# Altium schematic sheet voltage_sense.SchDoc
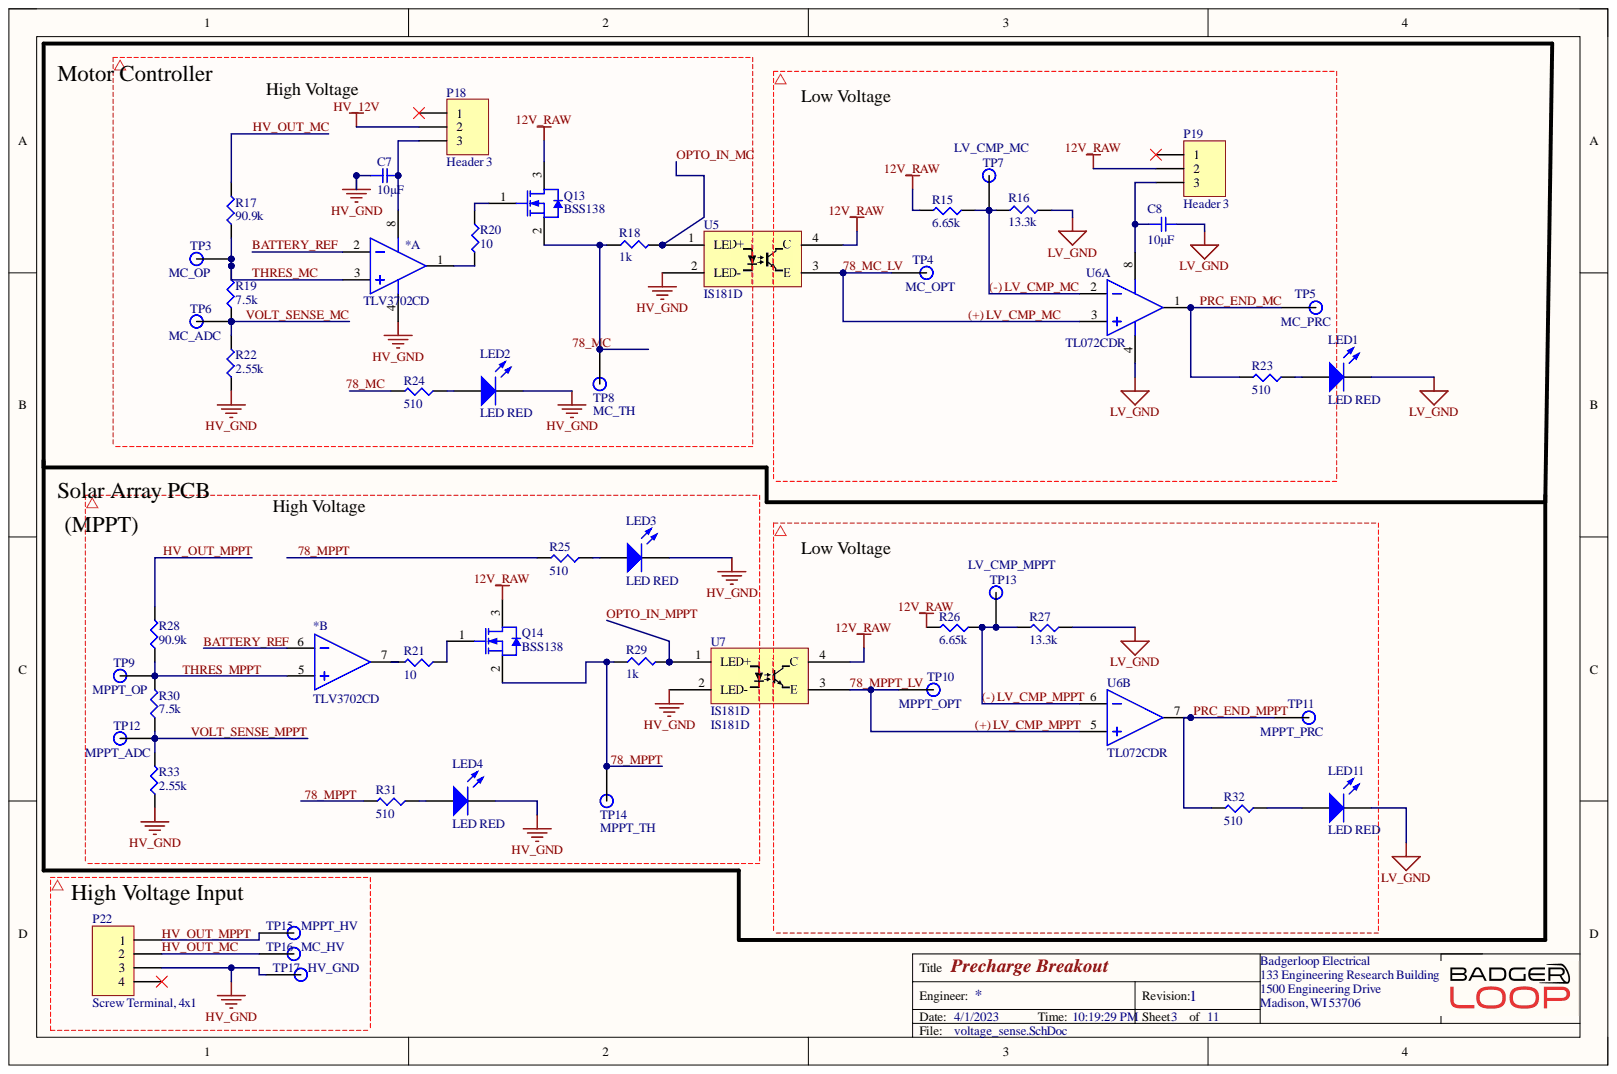
[diagram p0: voltage_sense.SchDoc]

## Parts
*  TLV3702CD  [TLV3702CD-Footprint-1]  (parts A,B)
C7  value 10µF  [SMD-0805C]  (hidden comment: GCM21BR71A106KE22L)
C8  value 10µF  [SMD-0805C]  (hidden comment: GCM21BR71A106KE22L)
LED1  LED RED  [LED 0603 ORANGE]
LED2  LED RED  [LED 0603 ORANGE]
LED3  LED RED  [LED 0603 ORANGE]
LED4  LED RED  [LED 0603 ORANGE]
LED11  LED RED  [LED 0603 ORANGE]
P18  Header 3  [Pin Header 1x3 TH Pitch 2.54mm]
P19  Header 3  [Pin Header 1x3 TH Pitch 2.54mm]
P22  Screw Terminal, 4x1  [4POS TERM BLOCK]
Q13  BSS138  [FAIR-SOT23-3_V]
Q14  BSS138  [FAIR-SOT23-3_V]
R15  value 6.65k  [SMD-0805-RES]  (hidden comment: ERJ-6ENF6651V)
R16  value 13.3k  [SMD-0805-RES]  (hidden comment: ERJ-6ENF1332V)
R17  value 90.9k  [SMD-1206-RES]  (hidden comment: ERJ-8ENF9092V)
R18  value 1k  [SMD-0805-RES]  (hidden comment: ERJ-P06F1001V)
R19  value 7.5k  [SMD-0805-RES]  (hidden comment: ERJ-6GEYJ752V)
R20  10  [SMD-0805-RES]
R21  10  [SMD-0805-RES]
R22  value 2.55k  [SMD-1206-RES]  (hidden comment: ERJ-8ENF2551V)
R23  value 510  [SMD-0805-RES]  (hidden comment: ERJ-P06J511V)
R24  value 510  [SMD-0805-RES]  (hidden comment: ERJ-P06J511V)
R25  value 510  [SMD-0805-RES]  (hidden comment: ERJ-P06J511V)
R26  value 6.65k  [SMD-0805-RES]  (hidden comment: ERJ-6ENF6651V)
R27  value 13.3k  [SMD-0805-RES]  (hidden comment: ERJ-6ENF1332V)
R28  value 90.9k  [SMD-1206-RES]  (hidden comment: ERJ-8ENF9092V)
R29  value 1k  [SMD-0805-RES]  (hidden comment: ERJ-P06F1001V)
R30  value 7.5k  [SMD-0805-RES]  (hidden comment: ERJ-6GEYJ752V)
R31  value 510  [SMD-0805-RES]  (hidden comment: ERJ-P06J511V)
R32  value 510  [SMD-0805-RES]  (hidden comment: ERJ-P06J511V)
R33  value 2.55k  [SMD-1206-RES]  (hidden comment: ERJ-8ENF2551V)
TP3  MC_OP  [Test Point]
TP4  MC_OPT  [Test Point]
TP5  MC_PRC  [Test Point]
TP6  MC_ADC  [Test Point]
TP7  LV_CMP_MC  [Test Point]
TP8  MC_TH  [Test Point]
TP9  MPPT_OP  [Test Point]
TP10  MPPT_OPT  [Test Point]
TP11  MPPT_PRC  [Test Point]
TP12  MPPT_ADC  [Test Point]
TP13  LV_CMP_MPPT  [Test Point]
TP14  MPPT_TH  [Test Point]
TP15  MPPT_HV  [Test Point]
TP16  MC_HV  [Test Point]
TP17  HV_GND  [Test Point]
U5  IS181D  [IS181D]
U6  TL072CDR  [SOP127P600X175-8N]  (parts A,B)
U7  IS181D  [IS181D]

## Nets
12V_RAW: P19.2 Q13.3 Q14.3 R15.2 R26.2 U5.4(C) U7.4(C)
HV_12V: P18.2
HV_GND: *.4 C7.1 LED2.2 LED3.2 LED4.2 P22.3 R22.1 R33.1 TP17.1 U5.2(LED-) U7.2(LED-)
LV_GND: C8.2 LED1.2 LED11.2 R16.2 R27.1 U6.4
78_MC: Q13.2 R18.1 R24.2 TP8.1
78_MC_LV: TP4.1 U5.3(E) U6.3
78_MPPT: Q14.2 R25.2 R29.1 R31.2 TP14.1
78_MPPT_LV: TP10.1 U6.5 U7.3(E)
(-) LV_CMP_MC: R15.1 R16.1 TP7.1 U6.2
(-) LV_CMP_MPPT: R26.1 R27.2 TP13.1 U6.6
BATTERY_REF: *.2 *.6
HV_OUT_MC: P22.2 R17.2 TP16.1
HV_OUT_MPPT: P22.1 R28.2 TP15.1
OPTO_IN_MC: R18.2 U5.1(LED+)
OPTO_IN_MPPT: R29.2 U7.1(LED+)
PRC_END_MC: R23.2 TP5.1 U6.1
THRES_MC: *.3 R17.1 R19.2 TP3.1
THRES_MPPT: *.5 R28.1 R30.2 TP9.1
VOLT_SENSE_MC: R19.1 R22.2 TP6.1
VOLT_SENSE_MPPT: R30.1 R33.2 TP12.1
Net*_1: *.1 R20.2
Net*_7: *.7 R21.2
Net*_8: *.8 C7.2 P18.3
NetC8_1: C8.1 P19.3 U6.8
NetLED1_1: LED1.1 R23.1
NetLED2_1: LED2.1 R24.1
NetLED3_1: LED3.1 R25.1
NetLED4_1: LED4.1 R31.1
NetLED11_1: LED11.1 R32.1
NetQ13_1: Q13.1 R20.1
NetQ14_1: Q14.1 R21.1
NetR32_2: R32.2 TP11.1 U6.7
PRC_END_MPPT:

Not connected: P18 1; P19 1; P22 4

## Library data of the parts
* = CMP-014-00010-1: Comparator, 2 Func, 7000uV Offset-Max, 240000ns Response Time, CMOS, PDSO8; Output Type: PUSH-PULL; Component Kind: Standard; Library Name: Altium Concord Pro on A365; Max Operating Temperature: 70°C; Min Operating Temperature: 0°C; RoHS Compliant: Yes
C7 C8 = CMP-001-00205-1: CAP CER 10UF 10V X7R 0805; Manufacturer: Murata Electronics North America; @ qty: 0; Minimum Quantity: 1; Tolerance: 10%; Unit Price (USD): 0.49; Applications: Automotive; Component Kind: Standard; Datasheets: http://www.murata.com/~/media/webrenewal/support/library/catalog/products/capacitor/mlcc/c03e.ashx; Design Item ID: GCM21BR71A106KE22L; Digi-Key Part Number: 490-10674-1-ND; Factory Stock: 0; Features: -; Height - Seated (Max): -; Image: //media.digikey.com/photos/Murata%20Photos/NCP,GCM%20SERIES%200805.jpg; Lead Spacing: -; Lead Style: -; Library Name: Altium Concord Pro on A365; Mounting Type: Surface Mount, MLCC; Operating Temperature: -55°C ~ 125°C; Package / Case: 0805 (2012 Metric); Packaging: Cut Tape (CT); Part Number: GCM21BR71A106KE22L; Part Status: Active; Quantity Available: 125344; Ratings: AEC-Q200; Series: GCM; Source: capacitors.SchLib; Temperature Coefficient: X7R; Voltage: 10V
LED1-LED4 LED11 = CMP-040-000018-1: A-0603UOC; Manufacturer: Lighthouse LEDs; Color: ORANGE; Minimum Quantity: 1; Availability: In Stock; Component Kind: Standard; ComponentLink1Description: Manufacturer; ComponentLink1URL: https://lighthouseleds.com/0603-smd-led-orange-ultra-bright-170-mcd.html; Datasheets: https://lighthouseleds.com/downloads/dl/file/id/11/0603_orange_amber_smd_led_datasheet.pdf; Design Item ID: LED ORANGE 0603; Library Name: Altium Concord Pro on A365; Manufacturer P/N: A-0603UOC; Package / Case: 0603; Source: misc.SchLib
P18 P19 = CMP-002-00073-1: Header, 3-Pin; Component Kind: Standard; Design Item ID: Header 3; LatestRevisionDate: 17-Jul-2002; LatestRevisionNote: Re-released for DXP Platform.; Library Name: Altium Concord Pro on A365; Source: connector.SchLib; Type: Connector; Publisher: Altium Limited
P22 = CMP-008-000002-1: Screw Terminal, 4-Pin; Component Kind: Standard; Design Item ID: Screw Terminal, 4x1; LatestRevisionDate: 17-Jul-2002; LatestRevisionNote: Re-released for DXP Platform.; Library Name: Altium Concord Pro on A365; Source: connector.SchLib; Type: Connector; Publisher: Altium Limited
Q13 Q14 = CMP-013-00021-4: N-Channel Logic Level Enhancement Mode Field Effect Transistor, 220 mA, 50 V, -55 to 150 degC, 3-Pin SOT-23, RoHS, Tape and Reel; Manufacturer: Fairchild; Elements: 1; Input Capacitance: 27pF; Nominal Dual Supply Voltage: 50V; Nominal Vgs: 1.3V; Package Version: Rev. 12, 03/2016; Power: 360mW; Rds On Max: 3.5Ohm; Resistance: 0.7Ohm; Typical Rise Time: 9ns; Case/Package: TO-236-3; Component Kind: Standard; Datasheet URL: https://www.fairchildsemi.com/datasheets/BS/BSS138.pdf; Datasheet Version: Rev. C, 10/2005; Library Name: Altium Concord Pro on A365; Max Operating Temperature: 150°C; Min Operating Temperature: -55°C; Mounting Technology: SM; Package Description: 3-Pin Small Outline Transistor, Body 2.92 x 1.325 mm, Pitch 0.95 mm; Package Quantity: 1; Package Reference: SOT23-3; Packaging: TapeandReel; Pins: 3; RoHS Compliant: True
R15 R26 = CMP-009-01502-1: RES SMD 6.65K OHM 1% 1/8W 0805; Manufacturer: Panasonic Electronic Components; @ qty: 1500; Factory Stock: 0; Minimum Quantity: 1; Power (Watts): 0.125W, 1/8W; Temperature Coefficient: ±100ppm/°C; Unit Price (USD): 0.00729; Component Kind: Standard; Composition: Thick Film; Datasheets: http://industrial.panasonic.com/www-cgi/jvcr13pz.cgi?E+PZ+3+AOA0002+ERJ6ENF6651V+7+WW; Design Item ID: ERJ-6ENF6651V; Digi-Key Part Number: P6.65KCCT-ND; Failure Rate: -; Features: Automotive AEC-Q200; Height - Seated (Max): 0.028" (0.70mm); Image: //media.digikey.com/Renders/Panasonic%20Renders/ERJ-0805%20Pkg.jpg; Library Name: Altium Concord Pro on A365; Number of Terminations: 2; Operating Temperature: -55°C ~ 155°C; Package / Case: 0805 (2012 Metric); Packaging: Cut Tape (CT); Part Number: ERJ-6ENF6651V; Part Status: Active; Quantity Available: 36879; Series: ERJ; Size / Dimension: 0.079" L x 0.049" W (2.00mm x 1.25mm); Source: resistors.SchLib; Supplier Device Package: 0805; Tolerance: 1%
R16 R27 = CMP-009-01074-1: RES SMD 13.3K OHM 1% 1/8W 0805; Manufacturer: Panasonic Electronic Components; @ qty: 1500; Factory Stock: 0; Minimum Quantity: 1; Power (Watts): 0.125W, 1/8W; Temperature Coefficient: ±100ppm/°C; Unit Price (USD): 0.00729; Component Kind: Standard; Composition: Thick Film; Datasheets: http://industrial.panasonic.com/www-cgi/jvcr13pz.cgi?E+PZ+3+AOA0002+ERJ6ENF1332V+7+WW; Design Item ID: ERJ-6ENF1332V; Digi-Key Part Number: P13.3KCCT-ND; Failure Rate: -; Features: Automotive AEC-Q200; Height - Seated (Max): 0.028" (0.70mm); Image: //media.digikey.com/Renders/Panasonic%20Renders/ERJ-0805%20Pkg.jpg; Library Name: Altium Concord Pro on A365; Number of Terminations: 2; Operating Temperature: -55°C ~ 155°C; Package / Case: 0805 (2012 Metric); Packaging: Cut Tape (CT); Part Number: ERJ-6ENF1332V; Part Status: Active; Quantity Available: 24077; Series: ERJ; Size / Dimension: 0.079" L x 0.049" W (2.00mm x 1.25mm); Source: resistors.SchLib; Supplier Device Package: 0805; Tolerance: 1%
R17 R28 = CMP-009-02429-1: RES SMD 90.9K OHM 1% 1/4W 1206; Manufacturer: Panasonic Electronic Components; @ qty: 1500; Factory Stock: 0; Minimum Quantity: 1; Power (Watts): 0.25W, 1/4W; Temperature Coefficient: ±100ppm/°C; Unit Price (USD): 0.00933; Component Kind: Standard; Composition: Thick Film; Datasheets: http://industrial.panasonic.com/www-cgi/jvcr13pz.cgi?E+PZ+3+AOA0002+ERJ8ENF9092V+7+WW; Design Item ID: ERJ-8ENF9092V; Digi-Key Part Number: P90.9KFCT-ND; Failure Rate: -; Features: Automotive AEC-Q200; Image: //media.digikey.com/Renders/Panasonic%20Renders/ERJ-1206%20Pkg.jpg; Library Name: Altium Concord Pro on A365; Number of Terminations: 2; Operating Temperature: -55°C ~ 155°C; Package / Case: 1206 (3216 Metric); Packaging: Cut Tape (CT); Part Number: ERJ-8ENF9092V; Part Status: Active; Quantity Available: 48984; Series: ERJ; Source: resistors.SchLib; Supplier Device Package: 1206; Tolerance: 1%
R18 R29 = CMP-009-02659-1: RES SMD 1K OHM 1% 1/2W 0805; Manufacturer: Panasonic Electronic Components; @ qty: 1500; Factory Stock: 0; Minimum Quantity: 1; Power (Watts): 0.5W, 1/2W; Temperature Coefficient: ±100ppm/°C; Unit Price (USD): 0.02534; Component Kind: Standard; Composition: Thick Film; Datasheets: https://industrial.panasonic.com/cdbs/www-data/pdf/RDA0000/AOA0000C243.pdf; Design Item ID: ERJ-P06F1001V; Digi-Key Part Number: P16058CT-ND; Failure Rate: -; Features: Automotive AEC-Q200, Pulse Withstanding; Image: //media.digikey.com/Renders/Panasonic%20Renders/ERJ-0805%20Pkg.jpg; Library Name: Altium Concord Pro on A365; Number of Terminations: 2; Operating Temperature: -55°C ~ 155°C; Package / Case: 0805 (2012 Metric); Packaging: Cut Tape (CT); Part Number: ERJ-P06F1001V; Part Status: Active; Quantity Available: 161264; Series: ERJ; Source: resistors.SchLib; Supplier Device Package: 0805; Tolerance: 1%
R19 R30 = CMP-009-01751-1: RES SMD 7.5K OHM 5% 1/8W 0805; Manufacturer: Panasonic Electronic Components; @ qty: 1500; Factory Stock: 0; Minimum Quantity: 1; Power (Watts): 0.125W, 1/8W; Temperature Coefficient: ±200ppm/°C; Unit Price (USD): 0.00496; Component Kind: Standard; Composition: Thick Film; Datasheets: http://industrial.panasonic.com/www-cgi/jvcr13pz.cgi?E+PZ+3+AOA0001+ERJ6GEYJ752V+7+WW; Design Item ID: ERJ-6GEYJ752V; Digi-Key Part Number: P7.5KACT-ND; Failure Rate: -; Features: Automotive AEC-Q200; Image: //media.digikey.com/Renders/Panasonic%20Renders/ERJ-0805%20Pkg.jpg; Library Name: Altium Concord Pro on A365; Number of Terminations: 2; Operating Temperature: -55°C ~ 155°C; Package / Case: 0805 (2012 Metric); Packaging: Cut Tape (CT); Part Number: ERJ-6GEYJ752V; Part Status: Active; Quantity Available: 39543; Series: ERJ; Source: resistors.SchLib; Supplier Device Package: 0805; Tolerance: 5%
R20 R21 = ERJ-6GEYJ100V: RES SMD 10 OHM 5% 1/8W 0805; Manufacturer: Panasonic Electronic Components; @ qty: 1500; Factory Stock: 0; Minimum Quantity: 1; Power (Watts): 0.125W, 1/8W; Temperature Coefficient: ±200ppm/°C; Unit Price (USD): 0.00496; Component Kind: Standard; Composition: Thick Film; Datasheets: http://industrial.panasonic.com/www-cgi/jvcr13pz.cgi?E+PZ+3+AOA0001+ERJ6GEYJ100V+7+WW; Digi-Key Part Number: P10ACT-ND; Failure Rate: -; Features: Automotive AEC-Q200; Height - Seated (Max): 0.028" (0.70mm); Image: //media.digikey.com/Renders/Panasonic%20Renders/ERJ-0805%20Pkg.jpg; Library Name: resistors.SchLib; Number of Terminations: 2; Operating Temperature: -55°C ~ 155°C; Package / Case: 0805 (2012 Metric); Packaging: Cut Tape (CT); Part Number: ERJ-6GEYJ100V; Part Status: Active; Quantity Available: 282033; Series: ERJ; Size / Dimension: 0.079" L x 0.049" W (2.00mm x 1.25mm); Supplier Device Package: 0805; Tolerance: ±5%; Value: 10
R22 R33 = CMP-009-02103-1: RES SMD 2.55K OHM 1% 1/4W 1206; Manufacturer: Panasonic Electronic Components; @ qty: 1500; Factory Stock: 0; Minimum Quantity: 1; Power (Watts): 0.25W, 1/4W; Temperature Coefficient: ±100ppm/°C; Unit Price (USD): 0.00933; Component Kind: Standard; Composition: Thick Film; Datasheets: http://industrial.panasonic.com/www-cgi/jvcr13pz.cgi?E+PZ+3+AOA0002+ERJ8ENF2551V+7+WW; Design Item ID: ERJ-8ENF2551V; Digi-Key Part Number: P2.55KFCT-ND; Failure Rate: -; Features: Automotive AEC-Q200; Image: //media.digikey.com/Renders/Panasonic%20Renders/ERJ-1206%20Pkg.jpg; Library Name: Altium Concord Pro on A365; Number of Terminations: 2; Operating Temperature: -55°C ~ 155°C; Package / Case: 1206 (3216 Metric); Packaging: Cut Tape (CT); Part Number: ERJ-8ENF2551V; Part Status: Active; Quantity Available: 30143; Series: ERJ; Source: resistors.SchLib; Supplier Device Package: 1206; Tolerance: 1%
R23-R25 R31 R32 = CMP-009-00645-1: RES SMD 510 OHM 5% 1/2W 0805; Manufacturer: Panasonic Electronic Components; @ qty: 1500; Factory Stock: 0; Minimum Quantity: 1; Power (Watts): 0.5W, 1/2W; Temperature Coefficient: ±200ppm/°C; Unit Price (USD): 0.01839; Component Kind: Standard; Composition: Thick Film; Datasheets: https://industrial.panasonic.com/cdbs/www-data/pdf/RDA0000/AOA0000C243.pdf; Design Item ID: ERJ-P06J511V; Digi-Key Part Number: P510ADCT-ND; Failure Rate: -; Features: Automotive AEC-Q200, Pulse Withstanding; Height - Seated (Max): 0.028" (0.70mm); Image: //media.digikey.com/Renders/Panasonic%20Renders/ERJ-0805%20Pkg.jpg; Library Name: Altium Concord Pro on A365; Number of Terminations: 2; Operating Temperature: -55°C ~ 155°C; Package / Case: 0805 (2012 Metric); Packaging: Cut Tape (CT); Part Number: ERJ-P06J511V; Part Status: Active; Quantity Available: 54515; Series: ERJ; Size / Dimension: 0.079" L x 0.049" W (2.00mm x 1.25mm); Source: resistors.SchLib; Supplier Device Package: 0805; Tolerance: 5%
TP3-TP17 = CMP-039-000000-1: SMD TEST POINT; Component Kind: Standard; Design Item ID: TP; Library Name: Altium Concord Pro on A365; Source: misc.SchLib
U5 U7 = CMP-020-000001-1: Transistor Output Optocoupler; Manufacturer: Isocom; Category: Optocouplers; Component Kind: Standard; ComponentLink1Description: https://datasheet.ciiva.com:443/pdfs/VipMasterIC/IC/ISCL/ISCL-S-A0004892693/ISCL-S-A0004892693-1.pdf?src-supplier=IHS+Markit; ComponentLink1URL: https://datasheet.ciiva.com:443/pdfs/VipMasterIC/IC/ISCL/ISCL-S-A0004892693/ISCL-S-A0004892693-1.pdf?src-supplier=IHS+Markit; ComponentLink2Description: https://datasheet.ciiva.com/7996/90946-7996982.pdf?src-supplier=Element14; ComponentLink2URL: https://datasheet.ciiva.com/7996/90946-7996982.pdf?src-supplier=Element14; Design Item ID: IS181D; Library Name: Altium Concord Pro on A365; Manufacturer Part Number: IS181D; Pricing 1: 1=0.59000, 10=0.36000, 100=0.20720, 1000=0.16218 (USD); RoHS: Yes; RoHS Compliant: Yes; Source: ic.SchLib; Stock 1: 15104
U6 = CMP-014-00006-1: IC OPAMP JFET 3MHZ 8SOIC; Manufacturer: Texas Instruments; Common Mode Rejection Ratio: 70dB; Dual Supply Voltage: 9V; Input Bias Current: 200pA; Input Offset Voltage (Vos): 10mV; Max Supply Voltage: 18V; Min Supply Voltage: 3.5V; Nominal Supply Current: 5mA; Number of Amplifiers: 2; Operating Supply Current: 1.4mA; Slew Rate: 13V/µs; Voltage Gain: 106.02dB; Bandwidth: 3MHz; Case/Package: D; Component Kind: Standard; ComponentLink1Description: http://www.ti.com/lit/ds/symlink/tl071a.pdf?src-supplier=Digi-Key; ComponentLink1URL: http://www.ti.com/lit/ds/symlink/tl071a.pdf?src-supplier=Digi-Key; ComponentLink2Description: http://www.ti.com/general/docs/lit/getliterature.tsp?genericPartNumber=TL072&&fileType=pdf&src-supplier=Avnet; ComponentLink2URL: http://www.ti.com/general/docs/lit/getliterature.tsp?genericPartNumber=TL072&&fileType=pdf&src-supplier=Avnet; ComponentLink3Description: http://www.farnell.com/datasheets/1882732.pdf?src-supplier=Element14; ComponentLink3URL: http://www.farnell.com/datasheets/1882732.pdf?src-supplier=Element14; Contact Plating: Gold; Design Item ID: TL072CDR; Gain Bandwidth Product: 3MHz; Height: 1.58mm; Lead Free: Lead Free; Length: 4.9mm; Library Name: Altium Concord Pro on A365; Manufacturer Part Number: TL072CDR; Max I/O Voltage: 10mV; Max Operating Temperature: 85°C; Min Operating Temperature: -40°C; Nominal Gain Bandwidth Product: 3MHz; Number of Channels: 2; Number of Circuits: 2; Number of Elements: 2; Package Quantity: 1; Packaging: Tape and Reel; PartId: 1; Pins: 8; Pricing 1: 1=0.41, 10=0.334, 100=0.2059, 500=0.1562, 1000=0.11715 (USD); Radiation Hardening: No; REACH SVHC: No SVHC; Source: ic.SchLib; Stock 1: 21821; Unity Gain Bandwidth Product: 3MHz; Width: 3.91mm
